# Altium schematic sheet schematic.SchDoc
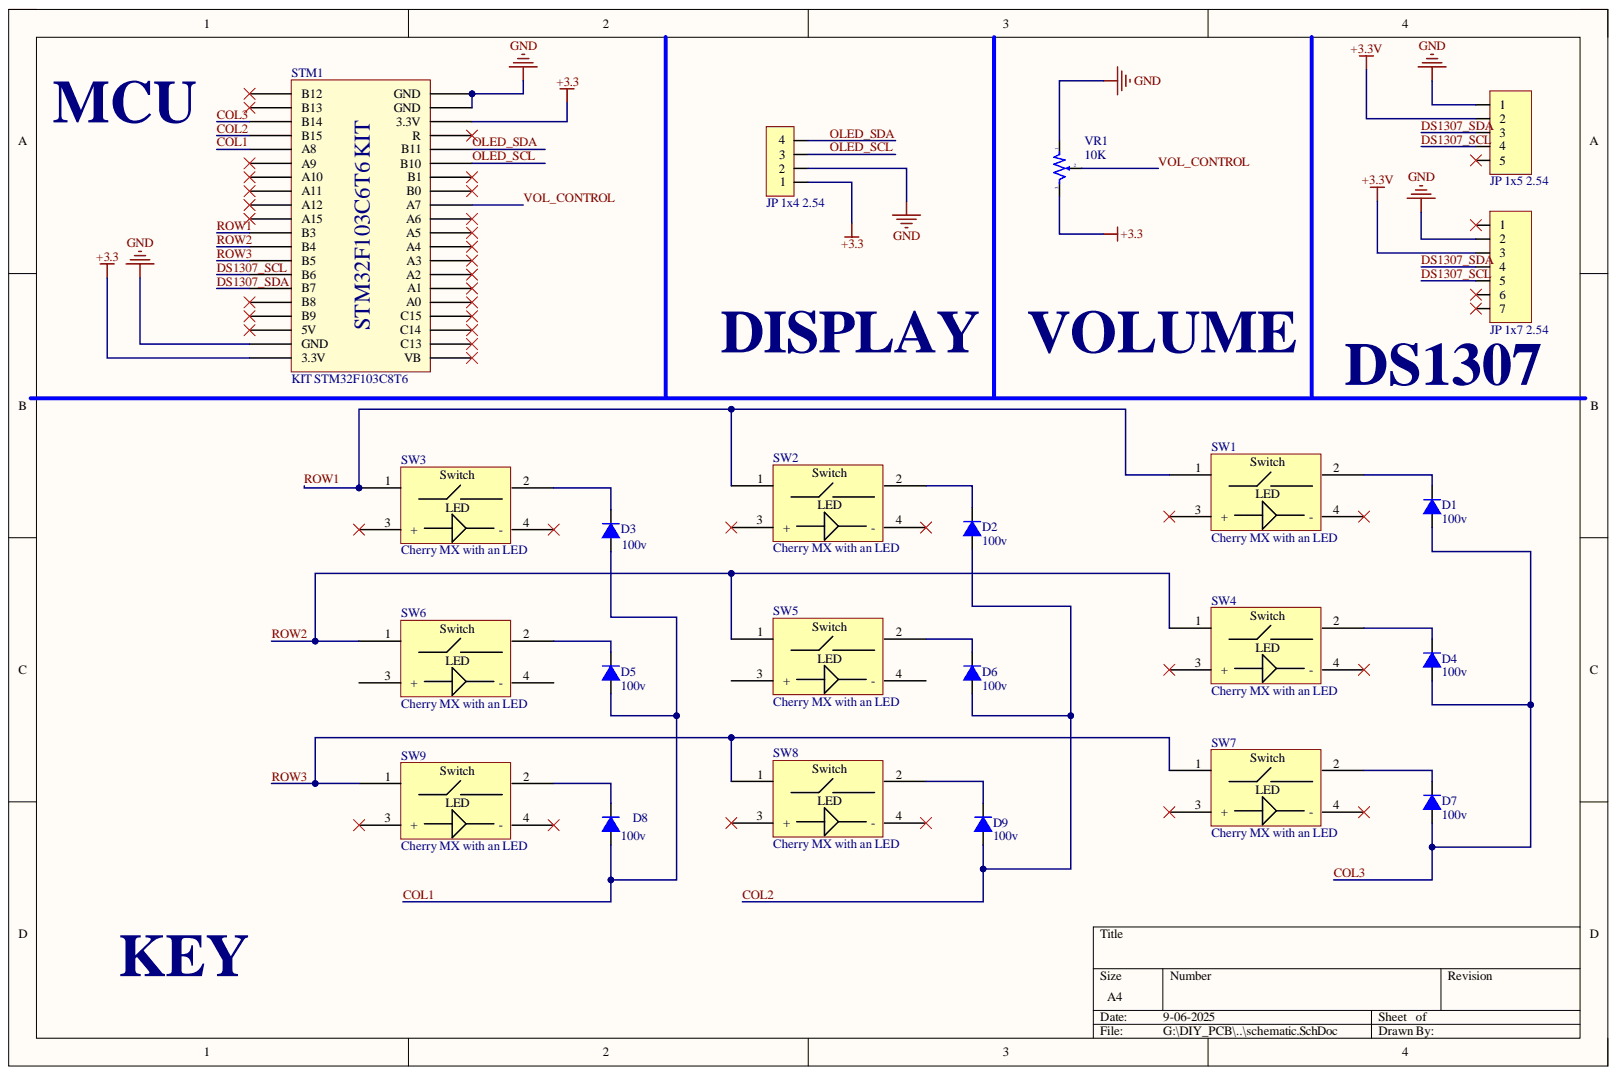
[diagram p0: schematic.SchDoc]

## Parts
D1  100v  [DIODE 0.5A]
D2  100v  [DIODE 0.5A]
D3  100v  [DIODE 0.5A]
D4  100v  [DIODE 0.5A]
D5  100v  [DIODE 0.5A]
D6  100v  [DIODE 0.5A]
D7  100v  [DIODE 0.5A]
D8  100v  [DIODE 0.5A]
D9  100v  [DIODE 0.5A]
JP1  JP 1x4 2.54  [JP 1x4 CT]
JP2  JP 1x5 2.54  [JP 1x5 CT]
JP3  JP 1x7 2.54  [JP 1x7 CT]
STM1  KIT STM32F103C8T6  [STM32F103 KIT]
SW1  Cherry MX with an LED  [MX_Cherry_LED]
SW2  Cherry MX with an LED  [MX_Cherry_LED]
SW3  Cherry MX with an LED  [MX_Cherry_LED]
SW4  Cherry MX with an LED  [MX_Cherry_LED]
SW5  Cherry MX with an LED  [MX_Cherry_LED]
SW6  Cherry MX with an LED  [MX_Cherry_LED]
SW7  Cherry MX with an LED  [MX_Cherry_LED]
SW8  Cherry MX with an LED  [MX_Cherry_LED]
SW9  Cherry MX with an LED  [MX_Cherry_LED]
VR1  10K  [Bien Tro Volume Don]

## Nets
+3.3: JP1.1 STM1.20(3.3V) STM1.38(3.3V) VR1.3
+3.3V: JP2.2 JP3.3
GND: JP1.2 JP2.1 JP3.2 STM1.19(GND) STM1.39(GND) STM1.40(GND) VR1.1
COL1: D3.1 D5.1 D8.1 STM1.5(A8)
COL2: D2.1 D6.1 D9.1 STM1.4(B15)
COL3: D1.1 D4.1 D7.1 STM1.3(B14)
DS1307_SCL: JP2.4 JP3.5 STM1.14(B6)
DS1307_SDA: JP2.3 JP3.4 STM1.15(B7)
OLED_SCL: JP1.3 STM1.35(B10)
OLED_SDA: JP1.4 STM1.36(B11)
ROW1: STM1.11(B3) SW1.1 SW2.1 SW3.1
ROW2: STM1.12(B4) SW4.1 SW5.1 SW6.1
ROW3: STM1.13(B5) SW7.1 SW8.1 SW9.1
VOL_CONTROL: STM1.32(A7) VR1.2
NetD1_2: D1.2 SW1.2
NetD2_2: D2.2 SW2.2
NetD3_2: D3.2 SW3.2
NetD4_2: D4.2 SW4.2
NetD5_2: D5.2 SW6.2
NetD6_2: D6.2 SW5.2
NetD7_2: D7.2 SW7.2
NetD8_2: D8.2 SW9.2
NetD9_2: D9.2 SW8.2

Not connected: JP2 5; JP3 1 6 7; STM1 1(B12) 2(B13) 6(A9) 7(A10) 8(A11) 9(A12) 10(A15) 16(B8) 17(B9) 18(5V) 21(VB) 22(C13) 23(C14) 24(C15) 25(A0) 26(A1) 27(A2) 28(A3) 29(A4) 30(A5) 31(A6) 33(B0) 34(B1) 37(R); SW1 3(+) 4(-); SW2 3(+) 4(-); SW3 3(+) 4(-); SW4 3(+) 4(-); SW5 3(+) 4(-); SW6 3(+) 4(-); SW7 3(+) 4(-); SW8 3(+) 4(-); SW9 3(+) 4(-)

## Library data of the parts
D1-D9 = Diode 1N4148: Cắm; Don_Vi: Con; Ma_Hang: MH001065; Ten_Linh_Kien: Diode 1N4148 DO35 450mA 100V ( 1N4148 DIP); Chung_Loai: Cắm; Component Kind: Standard; Library Name: Altium_Lib_by_KhueNguyenCreator.IntLib
JP1 = JP 1x4 2.54 Cai Thang: Cắm; Don_Vi: Con; Ma_Hang: MH004006; Ten_Linh_Kien: Jump Đơn Cái 2.54MM 1x20P Thẳng; Chung_Loai: Cắm; Component Kind: Standard; Library Name: Altium_Lib_by_KhueNguyenCreator.IntLib
JP2 = JP 1x5 2.54 Cai Thang: Cắm; Don_Vi: Con; Ma_Hang: MH004006; Ten_Linh_Kien: Jump Đơn Cái 2.54MM 1x20P Thẳng; Chung_Loai: Cắm; Component Kind: Standard; Library Name: Altium_Lib_by_KhueNguyenCreator.IntLib
JP3 = JP 1x7 2.54 Cai Thang: Cắm; Don_Vi: Con; Ma_Hang: MH004006; Ten_Linh_Kien: Jump Đơn Cái 2.54MM 1x20P Thẳng; Chung_Loai: Cắm; Component Kind: Standard; Library Name: Altium_Lib_by_KhueNguyenCreator.IntLib
STM1 = STM32f103 Bluepill: KIT STM32F103C8T6 Bluepill; Component Kind: Standard; Library Name: Altium_Lib_by_KhueNguyenCreator.IntLib
SW1-SW9 = MX_Cherry_LED: Component Kind: Standard; Library Name: PlumPot_Library.SchLib
VR1 = Triet Ap Don 10k: Cắm; Don_Vi: Con; Ma_Hang: MH001417; Ten_Linh_Kien: Triết Áp Đơn B10K; Chung_Loai: Cắm; Component Kind: Standard; Library Name: Altium_Lib_by_KhueNguyenCreator.IntLib
